# Altium schematic sheet CAN Data Diode With TXRX and Acknowledger Rev 4.SchDoc
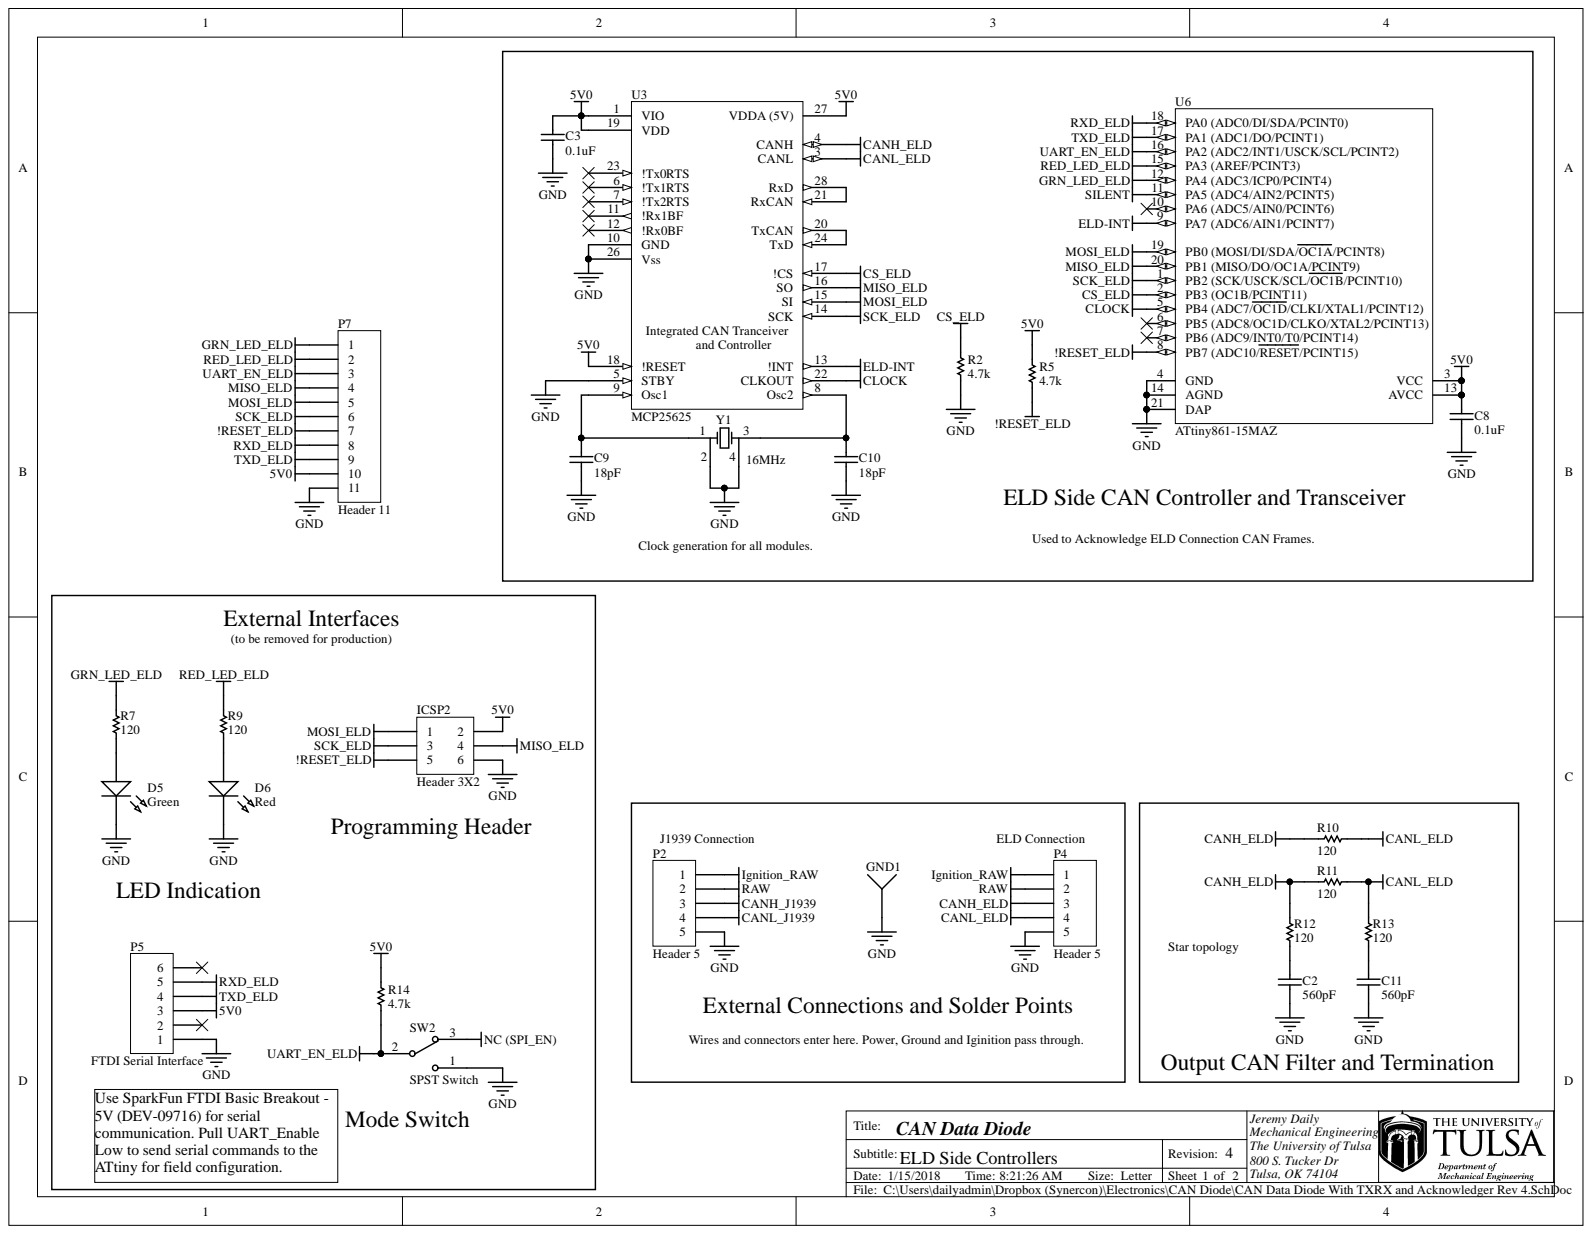
[diagram p0: CAN Data Diode With TXRX and Acknowledger Rev 4.SchDoc]

## Parts
C2  560pF  [0603]
C3  0.1uF  [0603]
C8  0.1uF  [0603]
C9  18pF  [0603]
C10  18pF  [0603]
C11  560pF  [0603]
D5  Green  [0805 Polarized]
D6  Red  [0805 Polarized]
GND1  Socket  [PIN1]  (value not drawn)
ICSP2  Header 3X2  [HDR2X3]
P2  Header 5  [HDR1X5]
P4  Header 5  [HDR1X5]
P5  FTDI Serial Interface  [HDR1X6]
P7  Header 11  [HDR1X11]
R2  4.7k  [0603]
R5  4.7k  [0603]
R7  120  [0603]
R9  120  [0603]
R10  120  [0603]
R11  120  [0603]
R12  120  [0603]
R13  120  [0603]
R14  4.7k  [0603]
SW2  SPST Switch  [Slide Switch R/A]
U3  MCP25625  [SOP65P780X200-28N]
U6  ATtiny861-15MAZ  [20PC_M]
Y1  16MHz  [XTAL 4 pad]

## Nets
5V0: C3.2 C8.2 ICSP2.2 P5.3 P7.10 R5.1 R14.1 U3.1(VIO) U3.18(!RESET) U3.19(VDD) U3.27(VDDA_(5V)) U6.3(VCC) U6.13(AVCC)
!RESET_ELD: ICSP2.5 P7.7 R5.2 U6.8(PB7_(ADC10/RESET/PCINT15))
CANH_ELD: P4.3 R10.2 R11.2 R12.1 U3.4(CANH)
CANH_J1939: P2.3
CANL_ELD: P4.4 R10.1 R11.1 R13.1 U3.3(CANL)
CANL_J1939: P2.4
CLOCK: U3.22(CLKOUT) U6.5(PB4_(ADC7/OC1D/CLKI/XTAL1/PCINT12))
CS_ELD: R2.1 U3.17(!CS) U6.2(PB3_(OC1B/PCINT11))
ELD-INT: U3.13(!INT) U6.9(PA7_(ADC6/AIN1/PCINT7))
GND: C2.1 C3.1 C8.1 C9.1 C10.2 C11.1 D5.2 D6.2 GND1.1 ICSP2.6 P2.5 P4.5 P5.1 P7.11 R2.2 SW2.1 U3.5(STBY) U3.10(GND) U3.26(Vss) U6.4(GND) U6.14(AGND) U6.21(DAP) Y1.2 Y1.4
GRN_LED_ELD: P7.1 R7.1 U6.12(PA4_(ADC3/ICP0/PCINT4))
Ignition_RAW: P2.1 P4.1
MISO_ELD: ICSP2.4 P7.4 U3.16(SO) U6.20(PB1_(MISO/DO/OC1A/PCINT9))
MOSI_ELD: ICSP2.1 P7.5 U3.15(SI) U6.19(PB0_(MOSI/DI/SDA/OC1A/PCINT8))
NC (SPI_EN): SW2.3
RAW: P2.2 P4.2
RED_LED_ELD: P7.2 R9.1 U6.15(PA3_(AREF/PCINT3))
RXD_ELD: P5.5 P7.8 U6.18(PA0_(ADC0/DI/SDA/PCINT0))
SCK_ELD: ICSP2.3 P7.6 U3.14(SCK) U6.1(PB2_(SCK/USCK/SCL/OC1B/PCINT10))
SILENT: U6.11(PA5_(ADC4/AIN2/PCINT5))
TXD_ELD: P5.4 P7.9 U6.17(PA1_(ADC1/DO/PCINT1))
UART_EN_ELD: P7.3 R14.2 SW2.2 U6.16(PA2_(ADC2/INT1/USCK/SCL/PCINT2))
NetC2_2: C2.2 R12.2
NetC9_2: C9.2 U3.9(Osc1) Y1.1
NetC10_1: C10.1 U3.8(Osc2) Y1.3
NetC11_2: C11.2 R13.2
NetD5_1: D5.1 R7.2
NetD6_1: D6.1 R9.2
NetU3_20: U3.20(TxCAN) U3.24(TxD)
NetU3_21: U3.21(RxCAN) U3.28(RxD)

Not connected: P5 2 6; U3 6(!Tx1RTS) 7(!Tx2RTS) 11(!Rx1BF) 12(!Rx0BF) 23(!Tx0RTS); U6 6(PB5_(ADC8/OC1D/CLKO/XTAL2/PCINT13)) 7(PB6_(ADC9/INT0/T0/PCINT14)) 10(PA6_(ADC5/AIN0/PCINT6))

## Library data of the parts
C2 C3 C8 C11 = Cap: 560pF ±10% 100V Ceramic Capacitor X7R 0603 (1608 Metric); Manufacturer: AVX Corporation; PartNumber: 06031C561K4T2A; Component Kind: Standard; Library Name: SSS Integrated Library.IntLib; Signal Integrity: Cap; Simulation: CAP; Supplier 1: Digi-Key; Supplier Part Number 1: 478-9867-1-ND
C9 C10 = Cap: 18pF ±5% 50V Ceramic Capacitor C0G, NP0 0603 (1608 Metric); Manufacturer: Kemet; PartNumber: C0603C180J5GACTU; Component Kind: Standard; Library Name: SSS Schematic Library.SchLib; Signal Integrity: Cap; Simulation: CAP; Supplier 1: Digi-Key; Supplier Part Number 1: 399-1052-1-ND
D5 = LED - Green: Green 570nm LED Indication - Discrete 2.2V 0805 (2012 Metric); Manufacturer: OSRAM Opto Semiconductors Inc.; PartNumber: LG R971-KN-1; Component Kind: Standard; Library Name: SSS Integrated Library.IntLib; Supplier 1: Digi-Key; Supplier Part Number 1: 475-1410-1-ND
D6 = LED - Red: Red 633nm LED Indication - Discrete 2V 0805 (2012 Metric); Manufacturer: OSRAM Opto Semiconductors Inc.; PartNumber: LS R976-NR-1; Component Kind: Standard; Library Name: SSS Integrated Library.IntLib; Supplier 1: Digi-Key; Supplier Part Number 1: 475-1278-1-ND
ICSP2 = Header 3X2: CONN HEADER 6POS DUAL .100 TIN; Manufacturer: 3M; PartNumber: 929836-01-03-RK; Component Kind: Standard; Library Name: Miscellaneous Connectors.IntLib; Signal Integrity: Connector; Supplier 1: Digi-Key; Supplier Part Number 1: 929836E-01-03-ND
P2 P4 = Header 5: Header, 5-Pin; Component Kind: Standard; Library Name: Miscellaneous Connectors.IntLib; Signal Integrity: Connector
P5 = Header 6: CONN HEADER .100 SNGL STR 6POS; Manufacturer: 3M; PartNumber: 929400-01-06-RK; Component Kind: Standard; Library Name: Miscellaneous Connectors.IntLib; Signal Integrity: Connector; Supplier 1: Digi-Key; Supplier Part Number 1: 929400E-01-06-ND
P7 = Header 11: Header, 11-Pin; Component Kind: Standard; LatestRevisionDate: 17-Jul-2002; LatestRevisionNote: Re-released for DXP Platform.; Library Name: Miscellaneous Connectors.IntLib; Signal Integrity: Connector; Publisher: Altium Limited
R2 R5 R14 = Chip Resistor: 4.7 kOhms ±1% 0.1W, 1/10W Chip Resistor 0603 (1608 Metric) Automotive AEC-Q200, High Voltage Thick Film; Manufacturer: Rohm Semiconductor; PartNumber: KTR03EZPF4701; Component Kind: Standard; Library Name: SSS Integrated Library.IntLib; Supplier 1: Digi-Key; Supplier Part Number 1: RHM4.7KAYCT-ND
R7 R9-R13 = Chip Resistor: 120 Ohm ±1% 0.1W, 1/10W Chip Resistor 0603 (1608 Metric) Automotive AEC-Q200 Thick Film; Manufacturer: Stackpole Electronics Inc.; PartNumber: RMCF0603FT120R; Component Kind: Standard; Library Name: SSS Integrated Library.IntLib; Supplier 1: Digi-Key; Supplier Part Number 1: RMCF0603FT120RCT-ND
SW2 = SPDT Slide Switch: SWITCH SLIDE SPDT 200MA 30V; Manufacturer: TE Connectivity AMP Connectors; PartNumber: 1825232-1; Component Kind: Standard; Library Name: SSS Integrated Library.IntLib; Supplier 1: Digi-Key; Supplier Part Number 1: A107673-ND
U3 = MCP25625: CAN Interface IC CAN Controller with Int. Transceiver  28-QFN; Manufacturer: Microchip; PartNumber: MCP25625-E/SS; Component Kind: Standard; Library Name: SSS Schematic Library.SchLib; Supplier 1: Digi-Key; Supplier Part Number 1: MCP25625-E/SS-ND
U6 = ATtiny861-15MAZ: AVR Automotive, AEC-Q100, AVR® ATtiny Microcontroller IC 8-Bit 16MHz 8KB (4K x 16) FLASH 20-QFN (4x4); Manufacturer: Microchip Technology; Component Kind: Standard; Library Name: Atmel Microcontroller AVR Automotive.IntLib; PartNumber: ATTINY861-15MAZ; Supplier 1: Digi-Key; Supplier Part Number 1: 1611-ATTINY861-15MAZCT-ND
Y1 = Crystal with Ground: 16MHz ±30ppm Crystal 18pF 30 Ohm -20°C ~ 70°C Through Hole HC49/US; Manufacturer: ECS Inc.; PartNumber: ECS-160-18-33Q-DS; Component Kind: Standard; Library Name: TU Custom Parts Schematics.SchLib; Supplier 1: Digi-Key; Supplier Part Number 1: XC1991CT-ND
GND1 = Socket: Component Kind: Standard; Description: Socket; Library Name: Miscellaneous Connectors.IntLib; Signal Integrity: Connector
